# Altium schematic sheet [03] - MICROCONTROLLER.SchDoc("[03] - MICROCONTROLLER")
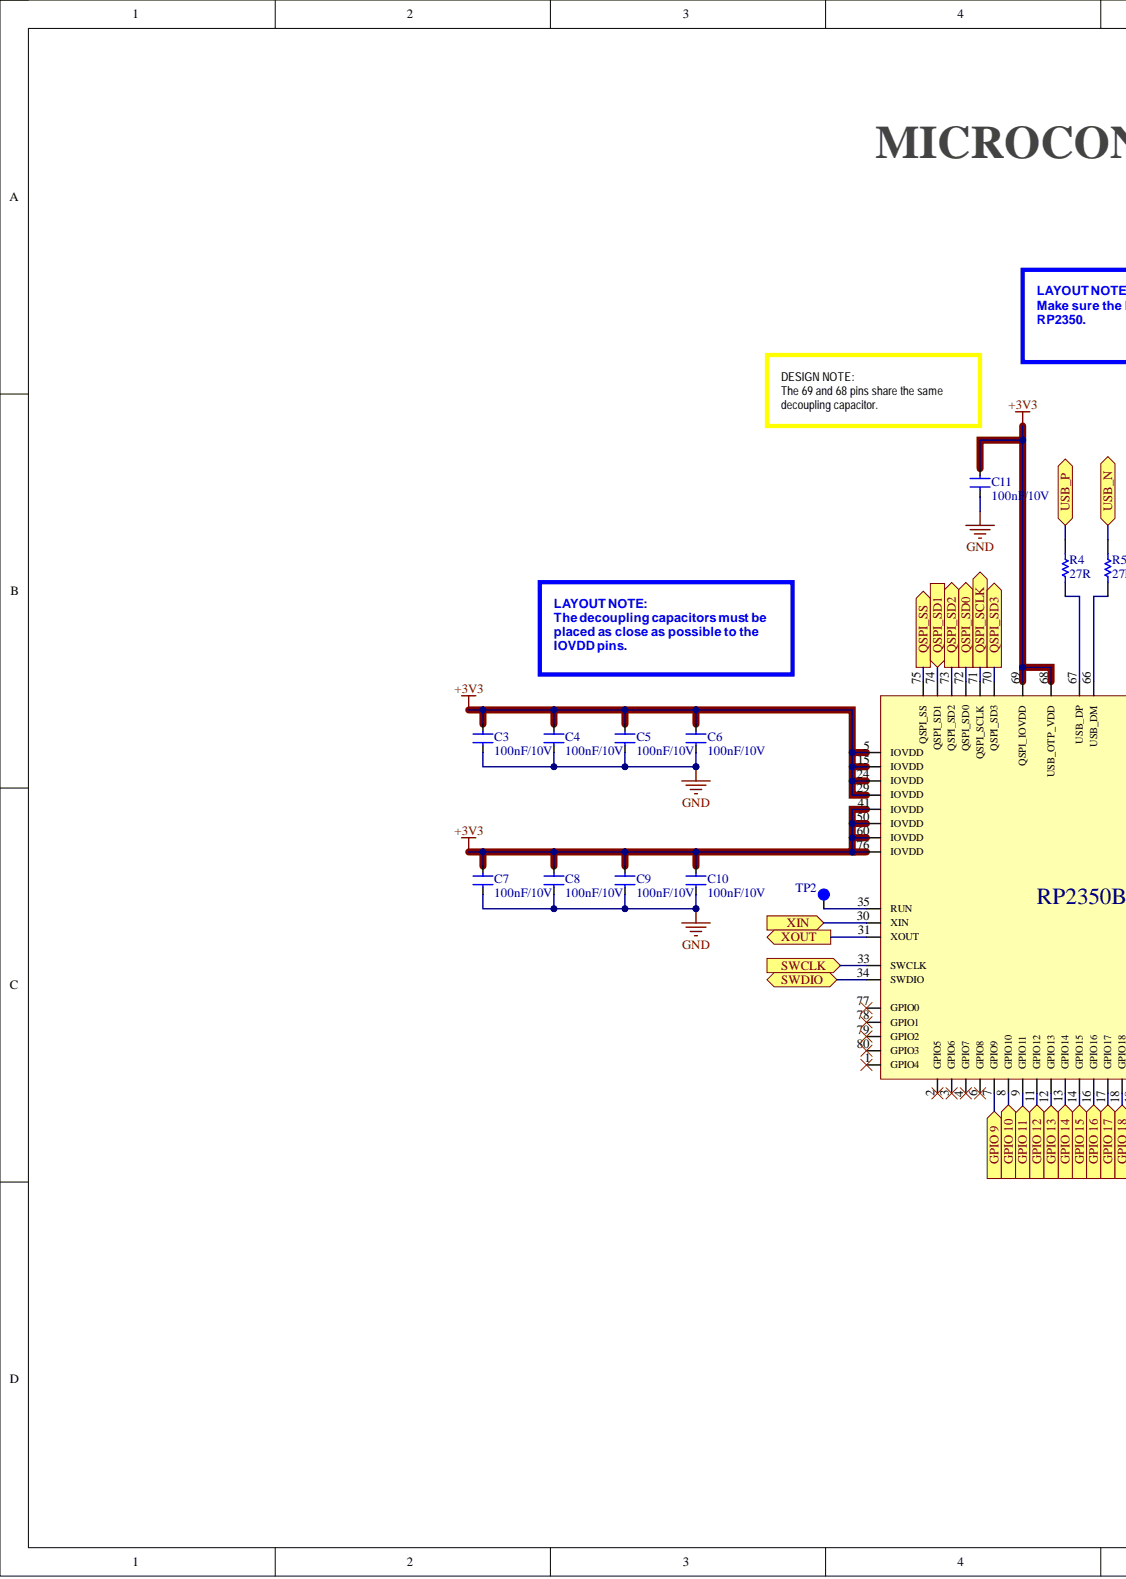
[diagram p0: [03] - MICROCONTROLLER.SchDoc, left]
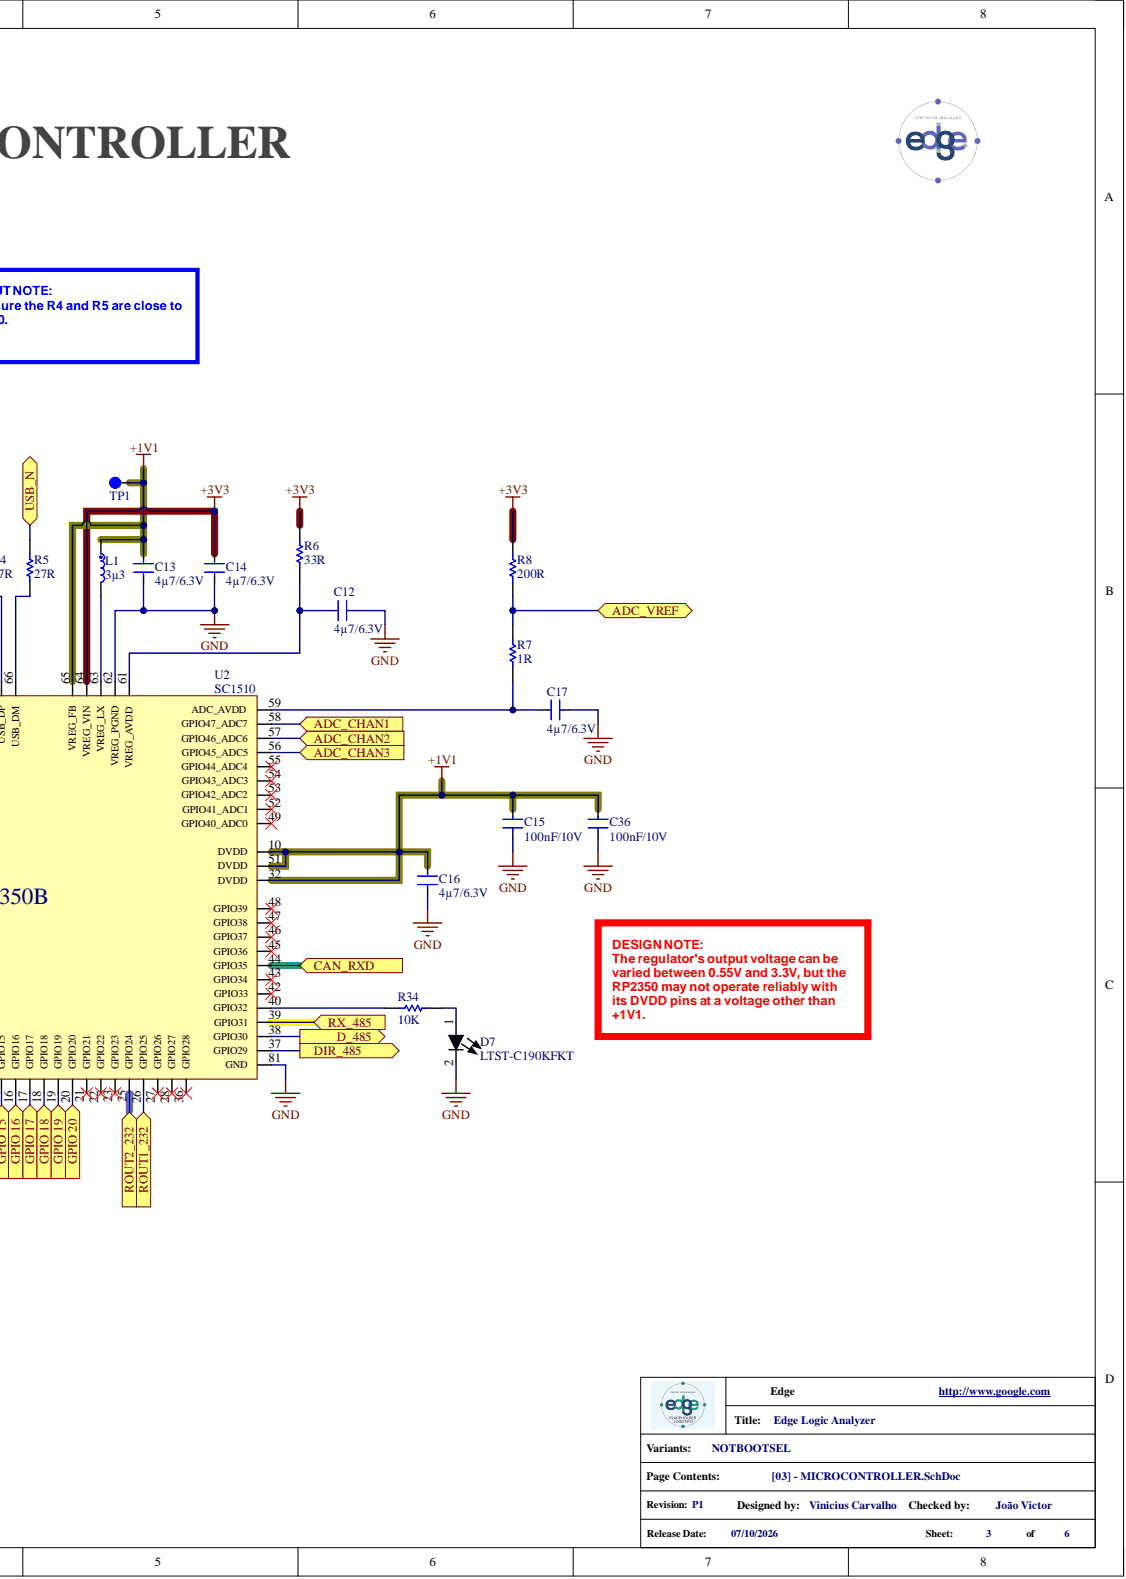
[diagram p1: [03] - MICROCONTROLLER.SchDoc, right]

## Parts
C3  100nF/10V  [C0402]
C4  100nF/10V  [C0402]
C5  100nF/10V  [C0402]
C6  100nF/10V  [C0402]
C7  100nF/10V  [C0402]
C8  100nF/10V  [C0402]
C9  100nF/10V  [C0402]
C10  100nF/10V  [C0402]
C11  100nF/10V  [C0402]
C12  4µ7/6.3V  [C0402]
C13  4µ7/6.3V  [C0402]
C14  4µ7/6.3V  [C0402]
C15  100nF/10V  [C0402]
C16  4µ7/6.3V  [C0402]
C17  4µ7/6.3V  [C0402]
C36  100nF/10V  [C0402]
D7  LTST-C190KFKT  [LTST-C190KFKT]
L1  3µ3  [L0806 - RP2350]
R4  27R  [R0402]
R5  27R  [R0402]
R6  33R  [R0402]
R7  1R  [R0603]
R8  200R  [R0402]
R34  10K  [R0402]
TP1  TestPoint  [Test Point]  (value not drawn)  (no BOM)
TP2  TestPoint  [Test Point]  (value not drawn)  (no BOM)
U2  SC1510  [SC1510]

## Ports
ADC_CHAN1, ADC_CHAN2, ADC_CHAN3, ADC_VREF, CAN_RXD, D_485, DIR_485, GPIO 9, GPIO 10, GPIO 11, GPIO 12, GPIO 13, GPIO 14, GPIO 15, GPIO 16, GPIO 17, GPIO 18, GPIO 19, GPIO 20, QSPI_SCLK, QSPI_SD0, QSPI_SD1, QSPI_SD2, QSPI_SD3, QSPI_SS, ROUT1_232, ROUT2_232, RX_485, SWCLK, SWDIO, USB_N, USB_P, XIN, XOUT

## Nets
+1V1: C13.2 C15.2 C16.2 C36.2 L1.1 TP1.1 U2.10(DVDD) U2.32(DVDD) U2.51(DVDD) U2.65(VREG_FB)
+3V3: C3.1 C4.1 C5.1 C6.2 C7.1 C8.1 C9.1 C10.2 C11.1 C14.2 R6.2 R8.2 U2.5(IOVDD) U2.15(IOVDD) U2.24(IOVDD) U2.29(IOVDD) U2.41(IOVDD) U2.50(IOVDD) U2.60(IOVDD) U2.64(VREG_VIN) U2.68(USB_OTP_VDD) U2.69(QSPI_IOVDD) U2.76(IOVDD)
GND: C3.2 C4.2 C5.2 C6.1 C7.2 C8.2 C9.2 C10.1 C11.2 C12.2 C13.1 C14.1 C15.1 C16.1 C17.2 C36.1 D7.2 U2.62(VREG_PGND) U2.81(GND)
ADC_CHAN1: U2.58(GPIO47_ADC7) ; port ADC_CHAN1
ADC_CHAN2: U2.57(GPIO46_ADC6) ; port ADC_CHAN2
ADC_CHAN3: U2.56(GPIO45_ADC5) ; port ADC_CHAN3
ADC_VREF: R7.2 R8.1 ; port ADC_VREF
CAN_RXD: U2.44(GPIO35) ; port CAN_RXD
D_485: U2.38(GPIO30) ; port D_485
DIR_485: U2.37(GPIO29) ; port DIR_485
GPIO 9: U2.7(GPIO9) ; port GPIO 9
GPIO 10: U2.8(GPIO10) ; port GPIO 10
GPIO 11: U2.9(GPIO11) ; port GPIO 11
GPIO 12: U2.11(GPIO12) ; port GPIO 12
GPIO 13: U2.12(GPIO13) ; port GPIO 13
GPIO 14: U2.13(GPIO14) ; port GPIO 14
GPIO 15: U2.14(GPIO15) ; port GPIO 15
GPIO 16: U2.16(GPIO16) ; port GPIO 16
GPIO 17: U2.17(GPIO17) ; port GPIO 17
GPIO 18: U2.18(GPIO18) ; port GPIO 18
GPIO 19: U2.19(GPIO19) ; port GPIO 19
GPIO 20: U2.20(GPIO20) ; port GPIO 20
QSPI_SCLK: U2.71(QSPI_SCLK) ; port QSPI_SCLK
QSPI_SD0: U2.72(QSPI_SD0) ; port QSPI_SD0
QSPI_SD1: U2.74(QSPI_SD1) ; port QSPI_SD1
QSPI_SD2: U2.73(QSPI_SD2) ; port QSPI_SD2
QSPI_SD3: U2.70(QSPI_SD3) ; port QSPI_SD3
QSPI_SS: U2.75(QSPI_SS) ; port QSPI_SS
ROUT1_232: U2.26(GPIO25) ; port ROUT1_232
ROUT2_232: U2.25(GPIO24) ; port ROUT2_232
RX_485: U2.39(GPIO31) ; port RX_485
SWCLK: U2.33(SWCLK) ; port SWCLK
SWDIO: U2.34(SWDIO) ; port SWDIO
USB_N: R5.2 ; port USB_N
USB_P: R4.2 ; port USB_P
XIN: U2.30(XIN) ; port XIN
XOUT: U2.31(XOUT) ; port XOUT
NetC12_1: C12.1 R6.1 U2.61(VREG_AVDD)
NetC17_1: C17.1 R7.1 U2.59(ADC_AVDD)
NetD7_1: D7.1 R34.2
NetL1_2: L1.2 U2.63(VREG_LX)
NetR4_1: R4.1 U2.67(USB_DP)
NetR5_1: R5.1 U2.66(USB_DM)
NetR34_1: R34.1 U2.40(GPIO32)
NetTP2_1: TP2.1 U2.35(RUN)

Not connected: U2 1(GPIO4) 2(GPIO5) 3(GPIO6) 4(GPIO7) 6(GPIO8) 21(GPIO21) 22(GPIO22) 23(GPIO23) 27(GPIO26) 28(GPIO27) 36(GPIO28) 42(GPIO33) 43(GPIO34) 45(GPIO36) 46(GPIO37) 47(GPIO38) 48(GPIO39) 49(GPIO40_ADC0) 52(GPIO41_ADC1) 53(GPIO42_ADC2) 54(GPIO43_ADC3) 55(GPIO44_ADC4) 77(GPIO0) 78(GPIO1) 79(GPIO2) 80(GPIO3)

## Library data of the parts
C3-C11 C15 C36 = CL05B104KP5NNNC: MLCC - 100nF 10V X7R 0402 ±10% Ceramic Capacitor; Manufacturer 1: Samsung; .Mounting: SMT; Multilayer: Yes; Capacitor Type: Ceramic; Case/Package: 0402; Category: Ceramic Capacitors; Component Kind: Standard; ComponentLink1Description: https://datasheet.ciiva.com:443/pdfs/VipMasterIC/IC/SEMS/SEMS-S-A0008523115/SEMS-S-A0008523129-1.pdf?src-supplier=IHS+Markit; ComponentLink1URL: https://datasheet.ciiva.com:443/pdfs/VipMasterIC/IC/SEMS/SEMS-S-A0008523115/SEMS-S-A0008523129-1.pdf?src-supplier=IHS+Markit; ComponentLink2Description: https://datasheet.ciiva.com/15165/getdatasheetpartid-37100-15165107.pdf?src-supplier=Verical; ComponentLink2URL: https://datasheet.ciiva.com/15165/getdatasheetpartid-37100-15165107.pdf?src-supplier=Verical; ComponentLink3Description: https://datasheet.ciiva.com/16498/cl05b104kq5nnnc-16498491.pdf?src-supplier=Future+Electronics; ComponentLink3URL: https://datasheet.ciiva.com/16498/cl05b104kq5nnnc-16498491.pdf?src-supplier=Future+Electronics; Dielectric Material: Ceramic; Height: 0.5mm; JESD-609 Code: e3; Length: 1mm; Manufacturer Part Number 1: CL05B104KP5NNNC; Max Operating Temperature: 125°C; Min Operating Temperature: -55°C; Mounting Technology: Surface Mount; Package Shape: Rectangular; Package Style: SMTMeter; Packaging: Tape and Reel; Pins: 2; Pricing: 1=0,08, 10=0,004, 100=0,0036, 1000=0,0032 (USD); Rated DC Voltage (URdc): 10V; Reach SVHC Compliant: Yes; RoHS: Yes; RoHS Compliant: Yes; Stock: 29591550; Supplier: Digikey; Supplier Part Number: 1276-1002-1-ND; Temperature Characteristics Code: X7R; Terminal Finish: Nickel; Termination: Wraparound; Tolerance  (fill in as +-): 10%; Tolerance (fill in as +-): 10%; Value: 100nF; Width: 0.5mm; Library Name: Edge_logic_analyzer.SchLib
C12-C14 C16 C17 = CL05A475KQ5NRNC: MLCC - 4.7 µF 6.3V 0402 X5R ±10%  Ceramic Capacitor X5R; Manufacturer 1: Samsung; .Mounting: SMT; Multilayer: Yes; Value: 4.7uF; Capacitor Type: Ceramic; Case/Package: 0402; Category: Ceramic Capacitors; Component Kind: Standard; ComponentLink1Description: https://datasheet.ciiva.com:443/pdfs/VipMasterIC/IC/SEMS/SEMS-S-A0008521219/SEMS-S-A0008521124-1.pdf?src-supplier=IHS+Markit; ComponentLink1URL: https://datasheet.ciiva.com:443/pdfs/VipMasterIC/IC/SEMS/SEMS-S-A0008521219/SEMS-S-A0008521124-1.pdf?src-supplier=IHS+Markit; ComponentLink2Description: https://datasheet.ciiva.com/25793/cl05a475kq5nrnc-25793634.pdf?src-supplier=Digikey; ComponentLink2URL: https://datasheet.ciiva.com/25793/cl05a475kq5nrnc-25793634.pdf?src-supplier=Digikey; ComponentLink3Description: https://datasheet.ciiva.com/26838/getdatasheetpartid-353228-26838196.pdf?src-supplier=Verical; ComponentLink3URL: https://datasheet.ciiva.com/26838/getdatasheetpartid-353228-26838196.pdf?src-supplier=Verical; Dielectric Material: Ceramic; JESD-609 Code: e3; Manufacturer Part Number 1: CL05A475KQ5NRNC; Max Operating Temperature: 85°C; Min Operating Temperature: -55°C; Mounting Technology: Surface Mount; Package Shape: Rectangular; Packaging: Tape and Reel; Pins: 2; Pricing: 1=0,08, 10=0,026, 100=0,016, 1000=0,0112, 2500=0,0096 (USD); Rated DC Voltage (URdc): 6.3V; Reach SVHC Compliant: Yes; RoHS: Yes; RoHS Compliant: Yes; Stock: 761086; Supplier: Digikey; Supplier Part Number: 1276-1481-1-ND; Temperature Characteristics Code: X5R; Terminal Finish: Nickel; Termination: Wraparound; Tolerance  (fill in as +-): 10%; Tolerance (fill in as +-): 10%; Library Name: Edge_logic_analyzer.SchLib
D7 = LTST-C190KFKT: DIODE - LED  Orange 605nm LED Indication - Discrete 2V 0603 (1608 Metric); Manufacturer 1: Vishay Lite-On; .Mounting: SMT; Channels: 1; Forward Current: 30mA; Forward Voltage: 2.4V; Lens Style: WATER CLEAR; Luminous Intensity-Min: 130cd; Luminous Intensity-Nom: 90mcd; Optoelectronic Device Type: SINGLE COLOR LED; Overall Height: 0.8mm; Reverse Voltage-Max: 5V; Shape: Rectangular; Viewing Angle: 130°; Category: LEDs; Color: Orange; Color@wavelength: Orange; Component Kind: Standard; ComponentLink1Description: https://datasheet.ciiva.com:443/pdfs/VipMasterIC/IC/LITE/LITE-S-A0008267416/LITE-S-A0008267416-1.pdf?src-supplier=IHS+Markit; ComponentLink1URL: https://datasheet.ciiva.com:443/pdfs/VipMasterIC/IC/LITE/LITE-S-A0008267416/LITE-S-A0008267416-1.pdf?src-supplier=IHS+Markit; ComponentLink2Description: https://datasheet.ciiva.com/11774/0900766b80dc02a7-11774744.pdf?src-supplier=Rs; ComponentLink2URL: https://datasheet.ciiva.com/11774/0900766b80dc02a7-11774744.pdf?src-supplier=Rs; ComponentLink3Description: https://datasheet.ciiva.com/12400/100-ltst-c190kfkt-53640-12400769.pdf?src-supplier=Mouser; ComponentLink3URL: https://datasheet.ciiva.com/12400/100-ltst-c190kfkt-53640-12400769.pdf?src-supplier=Mouser; ComponentLink4Description: https://datasheet.ciiva.com/15201/getdatasheetpartid-309341-15201388.pdf?src-supplier=Verical; ComponentLink4URL: https://datasheet.ciiva.com/15201/getdatasheetpartid-309341-15201388.pdf?src-supplier=Verical; ComponentLink5Description: https://datasheet.ciiva.com/16396/lite-on20ltst-c190kfkt-16396246.pdf?src-supplier=Future+Electronics; ComponentLink5URL: https://datasheet.ciiva.com/16396/lite-on20ltst-c190kfkt-16396246.pdf?src-supplier=Future+Electronics; ComponentLink6Description: https://datasheet.ciiva.com/2109/s-110-ltst-c190kfkt-2109976.pdf?src-supplier=Digikey; ComponentLink6URL: https://datasheet.ciiva.com/2109/s-110-ltst-c190kfkt-2109976.pdf?src-supplier=Digikey; ComponentLink7Description: https://datasheet.ciiva.com/2109/s-110-ltst-c190kfkt-2109977.pdf?src-supplier=Digikey; ComponentLink7URL: https://datasheet.ciiva.com/2109/s-110-ltst-c190kfkt-2109977.pdf?src-supplier=Digikey; ComponentLink8Description: https://datasheet.ciiva.com/2109/s-110-ltst-c190kfkt-2109978.pdf?src-supplier=Digikey; ComponentLink8URL: https://datasheet.ciiva.com/2109/s-110-ltst-c190kfkt-2109978.pdf?src-supplier=Digikey; JESD-609 Code: e3; Manufacturer Part Number 1: LTST-C190KFKT; Max Operating Temperature: 85°C; Min Operating Temperature: -55°C; Mounting Technology: Surface Mount; Packaging: Tape and Reel; Pins: 2; Pricing: 4000=0,0337, 8000=0,0285, 24000=0,0224, 48000=0,022, 100000=0,0218 (USD); Reach SVHC Compliant: Yes; RoHS: Yes; RoHS Compliant: Yes; Size: 1.1mm; Stock: 8000; Supplier: Arrow Electronics; Supplier Part Number: LTST-C190KFKT; Terminal Finish: Tin; Terminal Pitch: 1.5mm; Library Name: Edge_logic_analyzer.SchLib
L1 = AOTA-B201610S3R3-101-T: IND - 3.3 µH 2.1A 0806 140mOhm Shielded Molded Inductor  Max 0806 (2016 Metric); Manufacturer 1: Abracon; .Mounting: SMT; Component Kind: Standard; Manufacturer Part Number 1: AOTA-B201610S3R3-101-T; Pricing: 1=0,22, 10=0,181, 100=0,1497, 1000=0,12251 (USD); RoHS: No; Stock: 2887; Supplier: Digikey; Supplier Part Number: 535-AOTA-B201610S3R3-101-TCT-ND; Library Name: Edge_logic_analyzer.SchLib
R4 R5 = ERJ-2RKF27R0X: RES- 27R 1/10W  0402 ±1% 0.1W Chip Resistor; Manufacturer 1: Panasonic; .Mounting: SMT; Package Height: 0.35mm; Package Length: 1mm; Package Width: 0.5mm; Power: 100mW; Rated Temperature: 70°C; Reference Standard: AEC-Q200; Temperature Coefficient (ppm/Cel): 100; Working Voltage: 50V; Case/Package: 0402; Category: Chip SMD Resistors; Component Kind: Standard; ComponentLink1Description: https://datasheet.ciiva.com:443/pdfs/VipMasterIC/IC/PANA/PANA-S-A0009889626/PANA-S-A0009889626-1.pdf?src-supplier=IHS+Markit; ComponentLink1URL: https://datasheet.ciiva.com:443/pdfs/VipMasterIC/IC/PANA/PANA-S-A0009889626/PANA-S-A0009889626-1.pdf?src-supplier=IHS+Markit; ComponentLink2Description: https://datasheet.ciiva.com/11821/0900766b80f80784-11821276.pdf?src-supplier=Rs; ComponentLink2URL: https://datasheet.ciiva.com/11821/0900766b80f80784-11821276.pdf?src-supplier=Rs; ComponentLink3Description: https://datasheet.ciiva.com/46373/1678782-46373578.pdf?src-supplier=Element14; ComponentLink3URL: https://datasheet.ciiva.com/46373/1678782-46373578.pdf?src-supplier=Element14; ComponentLink4Description: https://datasheet.ciiva.com/46373/1678782-46373579.pdf?src-supplier=Element14; ComponentLink4URL: https://datasheet.ciiva.com/46373/1678782-46373579.pdf?src-supplier=Element14; ComponentLink5Description: https://datasheet.ciiva.com/22681/1678782-22681159.pdf?src-supplier=Element14; ComponentLink5URL: https://datasheet.ciiva.com/22681/1678782-22681159.pdf?src-supplier=Element14; ComponentLink6Description: https://datasheet.ciiva.com/7891/aoa0000ce2-7891306.pdf?src-supplier=Element14; ComponentLink6URL: https://datasheet.ciiva.com/7891/aoa0000ce2-7891306.pdf?src-supplier=Element14; ComponentLink7Description: https://datasheet.ciiva.com/7891/426256-7891578.pdf?src-supplier=Element14; ComponentLink7URL: https://datasheet.ciiva.com/7891/426256-7891578.pdf?src-supplier=Element14; ComponentLink8Description: https://datasheet.ciiva.com/9191/aoa0000ce2-9191129.pdf?src-supplier=Avnet; ComponentLink8URL: https://datasheet.ciiva.com/9191/aoa0000ce2-9191129.pdf?src-supplier=Avnet; ComponentLink9Description: https://datasheet.ciiva.com/9191/aoa0000ce2-9191130.pdf?src-supplier=Avnet; ComponentLink9URL: https://datasheet.ciiva.com/9191/aoa0000ce2-9191130.pdf?src-supplier=Avnet; ComponentLink10Description: https://datasheet.ciiva.com/43467/1678782-43467165.pdf?src-supplier=Farnell; ComponentLink10URL: https://datasheet.ciiva.com/43467/1678782-43467165.pdf?src-supplier=Farnell; Construction: Rectangular; JESD-609 Code: e3; Manufacturer Part Number 1: ERJ-2RKF27R0X; Max Operating Temperature: 155°C; Min Operating Temperature: -55°C; Mounting Technology: Surface Mount; Package Shape: Rectangular; Packaging: Tape and Reel; Pins: 2; Pricing: 1=0,1, 10=0,028, 100=0,0138, 1000=0,00766, 2500=0,00632, 5000=0,00554 (USD); Reach SVHC Compliant: Yes; Resistor Type: FIXED RESISTOR; RoHS: Yes; RoHS Compliant: Yes; Stock: 682991; Supplier: Digikey; Supplier Part Number: P27.0LCT-ND; Technology: METAL GLAZE/THICK FILM; Terminal Finish: Nickel; Termination: Wraparound; Tolerance: 1%; Value: 27R; Library Name: Edge_logic_analyzer.SchLib
R6 = ERJ-2RKF33R0X: RES - 33R 1/10W 0402 ±1%  Chip Resistor; Manufacturer 1: Panasonic; .Mounting: SMT; Package Height: 0.35mm; Package Length: 1mm; Package Width: 0.5mm; Power: 100mW; Rated Temperature: 70°C; Reference Standard: AEC-Q200; Temperature Coefficient (ppm/Cel): 100; Working Voltage: 50V; Case/Package: 0402; Category: Chip SMD Resistors; Component Kind: Standard; ComponentLink1Description: https://datasheet.ciiva.com:443/pdfs/VipMasterIC/IC/PANA/PANA-S-A0009889626/PANA-S-A0009889626-1.pdf?src-supplier=IHS+Markit; ComponentLink1URL: https://datasheet.ciiva.com:443/pdfs/VipMasterIC/IC/PANA/PANA-S-A0009889626/PANA-S-A0009889626-1.pdf?src-supplier=IHS+Markit; ComponentLink2Description: https://datasheet.ciiva.com/11821/0900766b80f80784-11821292.pdf?src-supplier=Rs; ComponentLink2URL: https://datasheet.ciiva.com/11821/0900766b80f80784-11821292.pdf?src-supplier=Rs; ComponentLink3Description: https://datasheet.ciiva.com/5917/aoa0000ce2-5917817.pdf?src-supplier=Farnell; ComponentLink3URL: https://datasheet.ciiva.com/5917/aoa0000ce2-5917817.pdf?src-supplier=Farnell; ComponentLink4Description: https://datasheet.ciiva.com/43467/1678782-43467173.pdf?src-supplier=Farnell; ComponentLink4URL: https://datasheet.ciiva.com/43467/1678782-43467173.pdf?src-supplier=Farnell; ComponentLink5Description: https://datasheet.ciiva.com/9190/aoa0000ce2-9190507.pdf?src-supplier=Avnet; ComponentLink5URL: https://datasheet.ciiva.com/9190/aoa0000ce2-9190507.pdf?src-supplier=Avnet; ComponentLink6Description: https://datasheet.ciiva.com/24023/aoa0000ce2-24023999.pdf?src-supplier=Mouser; ComponentLink6URL: https://datasheet.ciiva.com/24023/aoa0000ce2-24023999.pdf?src-supplier=Mouser; ComponentLink7Description: https://datasheet.ciiva.com/46373/1678782-46373081.pdf?src-supplier=Element14; ComponentLink7URL: https://datasheet.ciiva.com/46373/1678782-46373081.pdf?src-supplier=Element14; ComponentLink8Description: https://datasheet.ciiva.com/46373/1678782-46373082.pdf?src-supplier=Element14; ComponentLink8URL: https://datasheet.ciiva.com/46373/1678782-46373082.pdf?src-supplier=Element14; ComponentLink9Description: https://datasheet.ciiva.com/7836/aoa0000ce2-7836490.pdf?src-supplier=Element14; ComponentLink9URL: https://datasheet.ciiva.com/7836/aoa0000ce2-7836490.pdf?src-supplier=Element14; ComponentLink10Description: https://datasheet.ciiva.com/7836/426256-7836813.pdf?src-supplier=Element14; ComponentLink10URL: https://datasheet.ciiva.com/7836/426256-7836813.pdf?src-supplier=Element14; ComponentLink11Description: https://datasheet.ciiva.com/16777/70260748-16777705.pdf?src-supplier=Allied+Electronics; ComponentLink11URL: https://datasheet.ciiva.com/16777/70260748-16777705.pdf?src-supplier=Allied+Electronics; Construction: Rectangular; JESD-609 Code: e3; Manufacturer Part Number 1: ERJ-2RKF33R0X; Max Operating Temperature: 155°C; Min Operating Temperature: -55°C; Mounting Technology: Surface Mount; Package Shape: Rectangular; Packaging: Tape and Reel; Pins: 2; Pricing: 1=0,1, 10=0,028, 100=0,0138, 1000=0,00766, 2500=0,00632, 5000=0,00554 (USD); Reach SVHC Compliant: Yes; Resistor Type: FIXED RESISTOR; RoHS: Yes; RoHS Compliant: Yes; Stock: 66806; Supplier: Digikey; Supplier Part Number: P33.0LCT-ND; Technology: METAL GLAZE/THICK FILM; Terminal Finish: Nickel; Termination: Wraparound; Tolerance: 1%; Value: 33R; Library Name: Edge_logic_analyzer.SchLib
R7 = RC0603FR-071RL: RES - 1R 1/10W  0603 ±1%; Manufacturer 1: Yageo; .Mounting: SMT; Additional Feature: LASER TRIMMABLE; Package Height: 0.45mm; Package Length: 1.6mm; Package Width: 0.8mm; Power: 100mW; Rated Temperature: 70°C; Temperature Coefficient (ppm/Cel): 200; Working Voltage: 75V; Case/Package: 0603; Category: Chip SMD Resistors; Component Kind: Standard; ComponentLink1Description: https://datasheet.ciiva.com:443/pdfs/VipMasterIC/IC/YAGO/YAGO-S-A0008145938/YAGO-S-A0008145938-1.pdf?src-supplier=IHS+Markit; ComponentLink1URL: https://datasheet.ciiva.com:443/pdfs/VipMasterIC/IC/YAGO/YAGO-S-A0008145938/YAGO-S-A0008145938-1.pdf?src-supplier=IHS+Markit; ComponentLink2Description: https://datasheet.ciiva.com/11808/0900766b80f96399-11808162.pdf?src-supplier=Rs; ComponentLink2URL: https://datasheet.ciiva.com/11808/0900766b80f96399-11808162.pdf?src-supplier=Rs; ComponentLink3Description: https://datasheet.ciiva.com/6547/pyu-r-int-thick-7-6547270.pdf?src-supplier=Digikey; ComponentLink3URL: https://datasheet.ciiva.com/6547/pyu-r-int-thick-7-6547270.pdf?src-supplier=Digikey; ComponentLink4Description: https://datasheet.ciiva.com/6547/pyu-r-int-thick-7-6547271.pdf?src-supplier=Digikey; ComponentLink4URL: https://datasheet.ciiva.com/6547/pyu-r-int-thick-7-6547271.pdf?src-supplier=Digikey; ComponentLink5Description: https://datasheet.ciiva.com/7746/1738695-7746296.pdf?src-supplier=Element14; ComponentLink5URL: https://datasheet.ciiva.com/7746/1738695-7746296.pdf?src-supplier=Element14; ComponentLink6Description: https://datasheet.ciiva.com/7746/1738695-7746297.pdf?src-supplier=Element14; ComponentLink6URL: https://datasheet.ciiva.com/7746/1738695-7746297.pdf?src-supplier=Element14; ComponentLink7Description: https://datasheet.ciiva.com/6547/pyu-r-int-thick-7-6547269.pdf?src-supplier=Digikey; ComponentLink7URL: https://datasheet.ciiva.com/6547/pyu-r-int-thick-7-6547269.pdf?src-supplier=Digikey; Construction: Chip; JESD-609 Code: e3; Manufacturer Part Number 1: RC0603FR-071RL; Max Operating Temperature: 155°C; Min Operating Temperature: -55°C; Mounting Technology: Surface Mount; Package Shape: Rectangular; Packaging: Tape and Reel; Pins: 2; Pricing: 1=0,11, 10=0,012, 100=0,0112, 1000=0,0102 (USD); Reach SVHC Compliant: Yes; Resistor Type: FIXED RESISTOR; RoHS: Yes; RoHS Compliant: Yes; Stock: 71794; Supplier: Digikey; Supplier Part Number: 311-1.00HRCT-ND; Technology: METAL GLAZE/THICK FILM; Terminal Finish: Nickel; Termination: Wraparound; Tolerance: 1%; Value: 1R; Library Name: Edge_logic_analyzer.SchLib
R8 = RT0402BRD07200RL: RES - 200R  0.0625W 0402 0.1%  50V Fixed Resistor, Thin Film; Manufacturer 1: Yageo; .Mounting: SMT; Additional Feature: HIGH PRECISION; Package Height: 0.3mm; Package Length: 1mm; Package Width: 0.5mm; Power: 62.5mW; Rated Temperature: 70°C; Temperature Coefficient (ppm/Cel): 25; Case/Package: 0402; Category: Chip SMD Resistors; Component Kind: Standard; ComponentLink1Description: https://datasheet.ciiva.com:443/pdfs/VipMasterIC/IC/YAGO/YAGO-S-A0008510989/YAGO-S-A0008510989-1.pdf?src-supplier=IHS+Markit; ComponentLink1URL: https://datasheet.ciiva.com:443/pdfs/VipMasterIC/IC/YAGO/YAGO-S-A0008510989/YAGO-S-A0008510989-1.pdf?src-supplier=IHS+Markit; ComponentLink2Description: https://datasheet.ciiva.com/12705/pyu-rt-1-to-0-05-rohs-l-4-12705967.pdf?src-supplier=Mouser; ComponentLink2URL: https://datasheet.ciiva.com/12705/pyu-rt-1-to-0-05-rohs-l-4-12705967.pdf?src-supplier=Mouser; ComponentLink3Description: https://datasheet.ciiva.com/46635/pyu-rt-1-to-0-05-rohs-l-5-46635174.pdf?src-supplier=Digikey; ComponentLink3URL: https://datasheet.ciiva.com/46635/pyu-rt-1-to-0-05-rohs-l-5-46635174.pdf?src-supplier=Digikey; ComponentLink4Description: https://datasheet.ciiva.com/2582/pyu-rt-1-to-0-05-rohs-l-4-2582220.pdf?src-supplier=Digikey; ComponentLink4URL: https://datasheet.ciiva.com/2582/pyu-rt-1-to-0-05-rohs-l-4-2582220.pdf?src-supplier=Digikey; Construction: Chip; Manufacturer Part Number 1: RT0402BRD07200RL; Max Operating Temperature: 155°C; Min Operating Temperature: -55°C; Mounting Technology: Surface Mount; Packaging: Tape and Reel; Pins: 2; Pricing: 1=0,198, 25=0,135, 100=0,098, 250=0,087, 500=0,077 (USD); Reach SVHC Compliant: Yes; Resistor Type: FIXED RESISTOR; RoHS: Yes; RoHS Compliant: Yes; Stock: 8493; Supplier: Avnet; Supplier Part Number: 49AK4062; Technology: THIN FILM; Termination: Wraparound; Tolerance: 0.1%; Value: 200R; Working Voltage: 50V; Library Name: Edge_logic_analyzer.SchLib
R34 = RC1005F103CS: RES - 10K 1/16W 0402 ±1% Chip Resistor; Manufacturer 1: Samsung; .Mounting: SMT; Package Height: 0.35mm; Package Length: 1mm; Package Width: 0.5mm; Power: 60mW; Temperature Coefficient (ppm/Cel): 100; Value: 10kR; Working Voltage: 50V; Case/Package: 0402; Category: Chip SMD Resistors; Component Kind: Standard; ComponentLink1Description: https://datasheet.ciiva.com:443/pdfs/VipMasterIC/IC/SEMS/SEMS-S-A0001326806/SEMS-S-A0001326806-1.pdf?src-supplier=IHS+Markit; ComponentLink1URL: https://datasheet.ciiva.com:443/pdfs/VipMasterIC/IC/SEMS/SEMS-S-A0001326806/SEMS-S-A0001326806-1.pdf?src-supplier=IHS+Markit; ComponentLink2Description: https://datasheet.ciiva.com/15196/getdatasheetpartid-504789-15196214.pdf?src-supplier=Verical; ComponentLink2URL: https://datasheet.ciiva.com/15196/getdatasheetpartid-504789-15196214.pdf?src-supplier=Verical; ComponentLink3Description: https://datasheet.ciiva.com/16481/rcseries-16481007.pdf?src-supplier=Future+Electronics; ComponentLink3URL: https://datasheet.ciiva.com/16481/rcseries-16481007.pdf?src-supplier=Future+Electronics; Construction: Chip; JESD-609 Code: e3; Manufacturer Part Number 1: RC1005F103CS; Max Operating Temperature: 125°C; Min Operating Temperature: -55°C; Mounting Technology: Surface Mount; Package Shape: Rectangular; Packaging: Tape and Reel; Pins: 2; Pricing: 1=0,1, 10=0,039, 100=0,0201, 1000=0,01175, 2500=0,0099, 5000=0,00882 (USD); Reach SVHC Compliant: Yes; Resistor Type: FIXED RESISTOR; RoHS: Yes; RoHS Compliant: Yes; Stock: 10935408; Supplier: Digikey; Supplier Part Number: 1276-3431-1-ND; Technology: METAL GLAZE/THICK FILM; Terminal Finish: Nickel; Tolerance: 1%; Library Name: Edge_logic_analyzer.SchLib
TP1 TP2 = Test_Point: MECH - Test Points; Library Name: Edge_logic_analyzer.SchLib
U2 = SC1510: IC - MCU - ARM Microcontrollers - MCU RP2350B 150MHz clock Dual Arm Cortex-M33 cores 520KB RAM 8KB on-chip QFN-80; Manufacturer 1: RASPBERRY-PI; .Mounting: SMT; Component Kind: Standard; Datasheet Link: https://datasheets.raspberrypi.com/rp2350/rp2350-datasheet.pdf; Height: 0.9mm; Manufacturer Part Number 1: SC1510; Mouser Part Number: 358-SC1510; Mouser Price/Stock: https://www.mouser.co.uk/ProductDetail/Raspberry-Pi/SC1510?qs=jcD%2FCkGBYePv%252BTwvNgU%2F3g%3D%3D; Library Name: Edge_logic_analyzer.SchLib
